# Altium schematic sheet [03] - MyUno SCHEMATIC.SchDoc
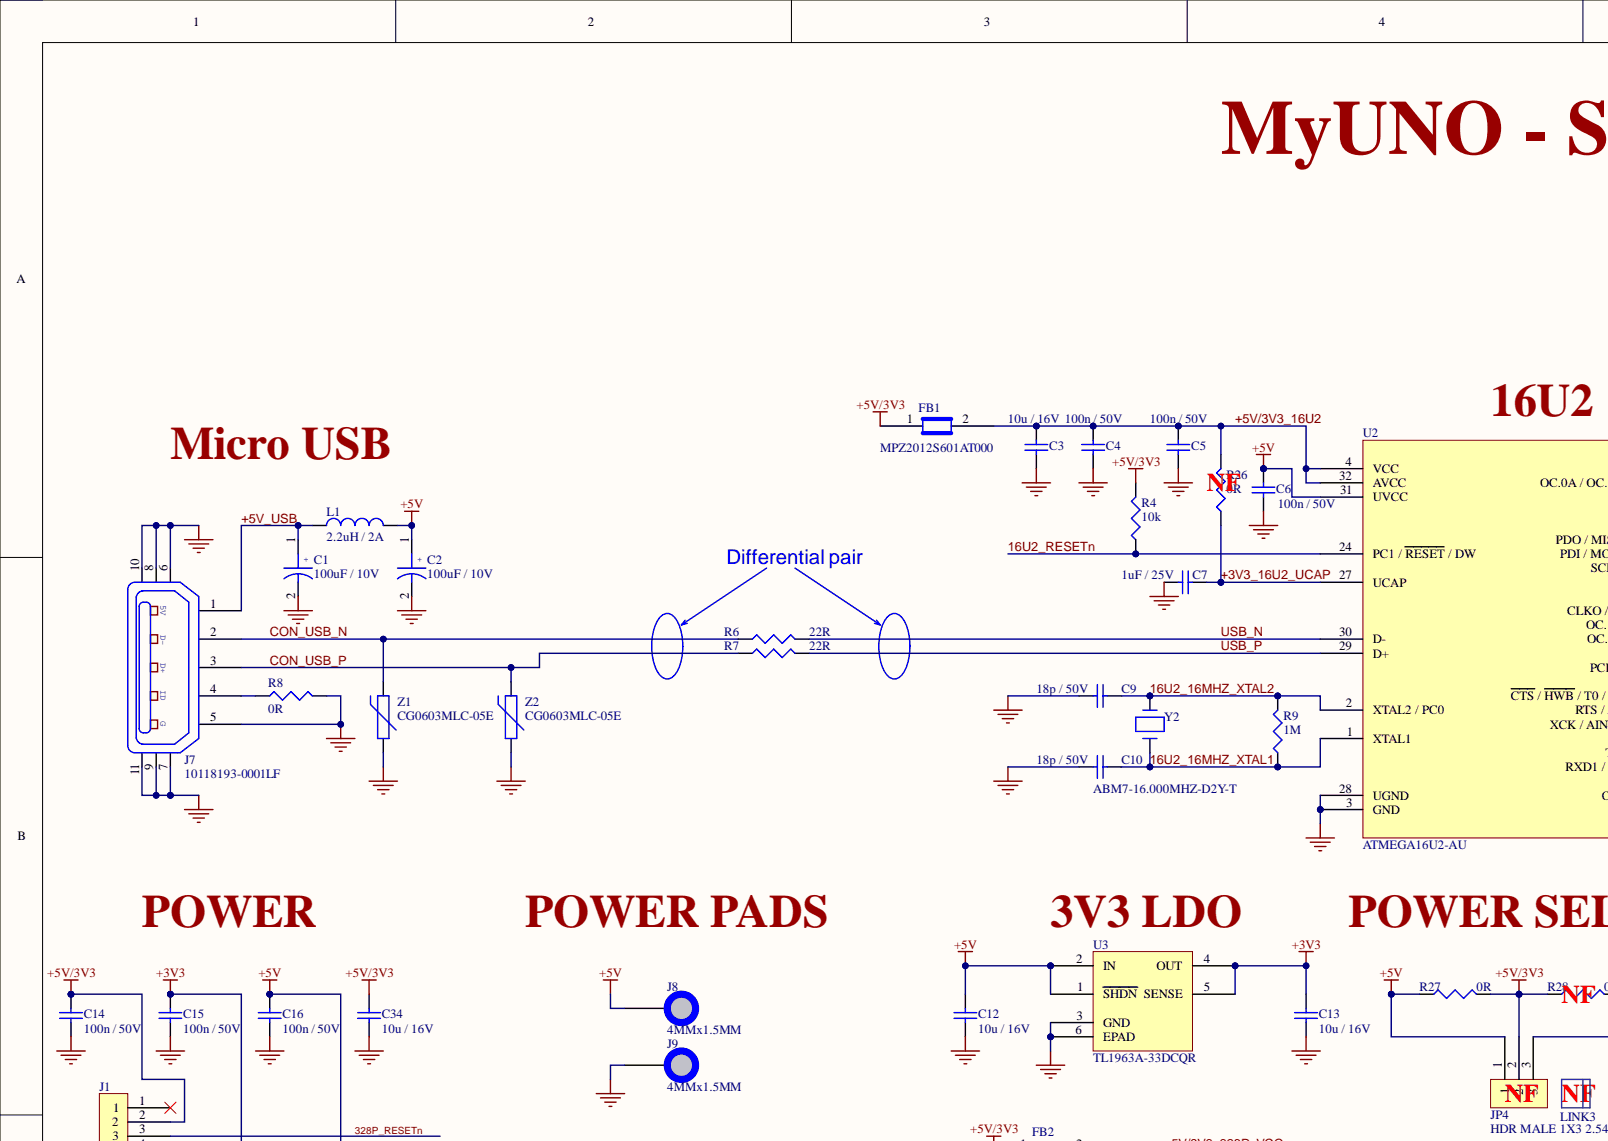
[diagram p0: [03] - MyUno SCHEMATIC.SchDoc, top left]
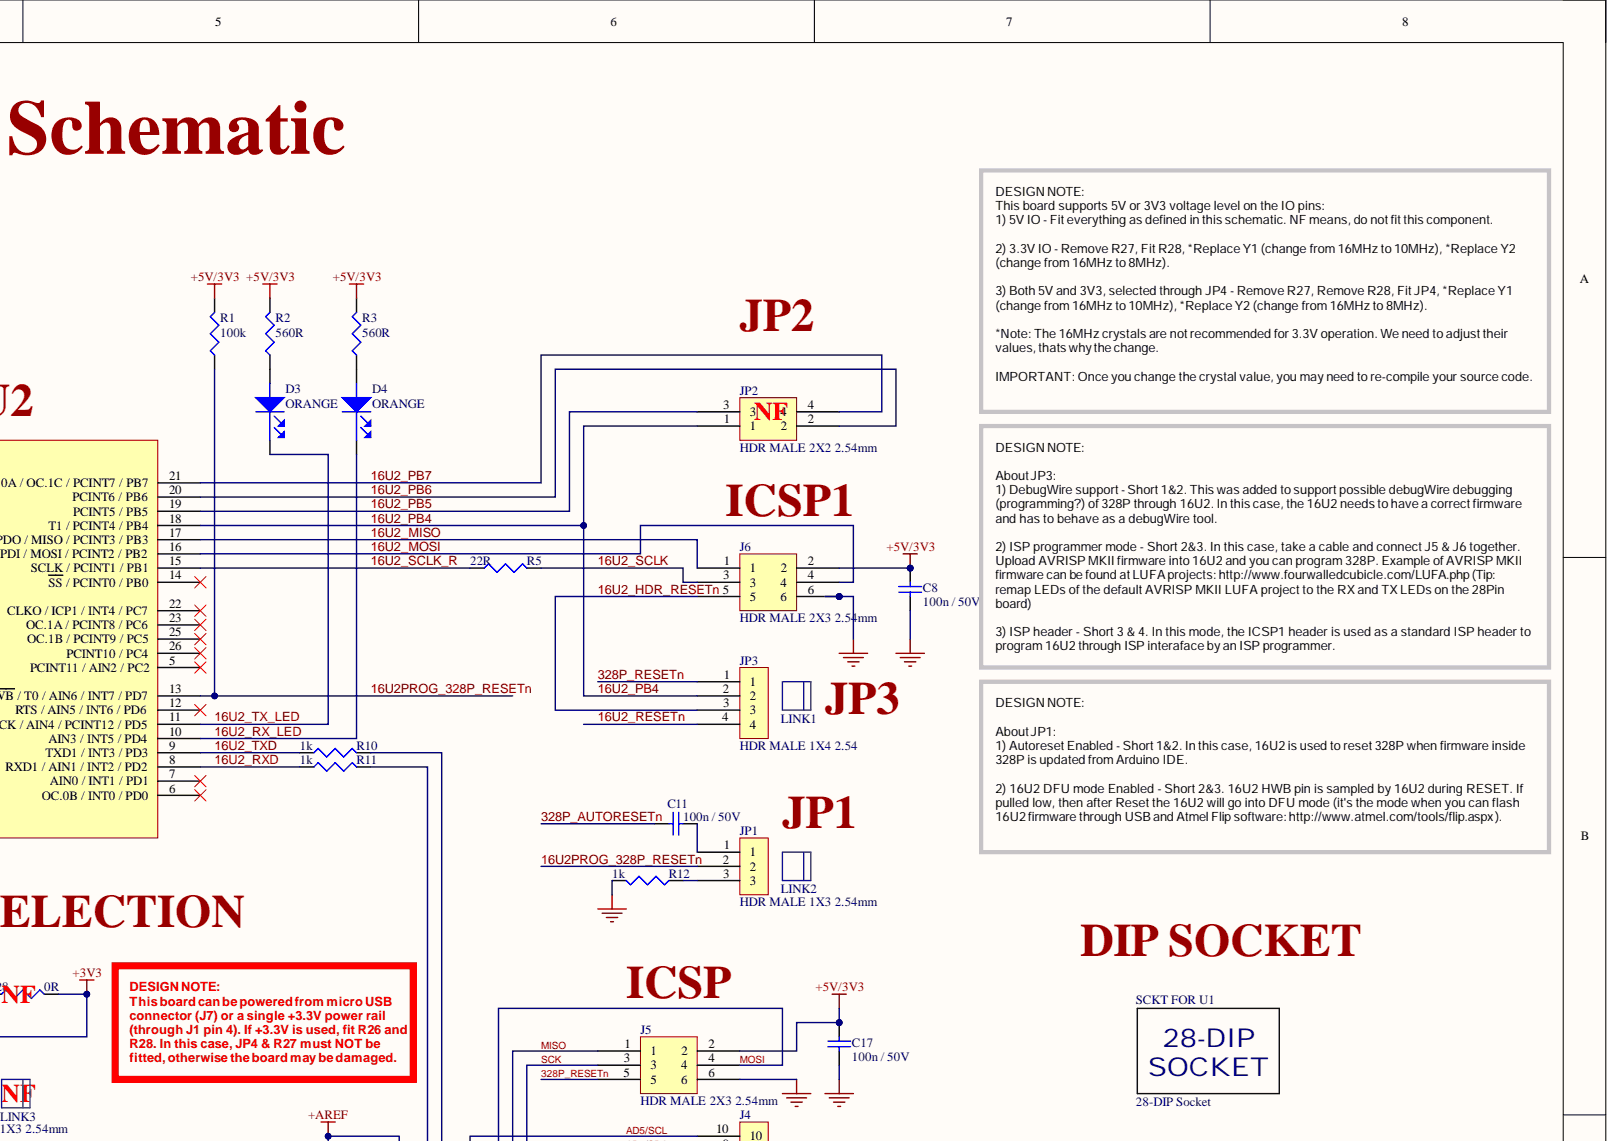
[diagram p1: [03] - MyUno SCHEMATIC.SchDoc, top right]
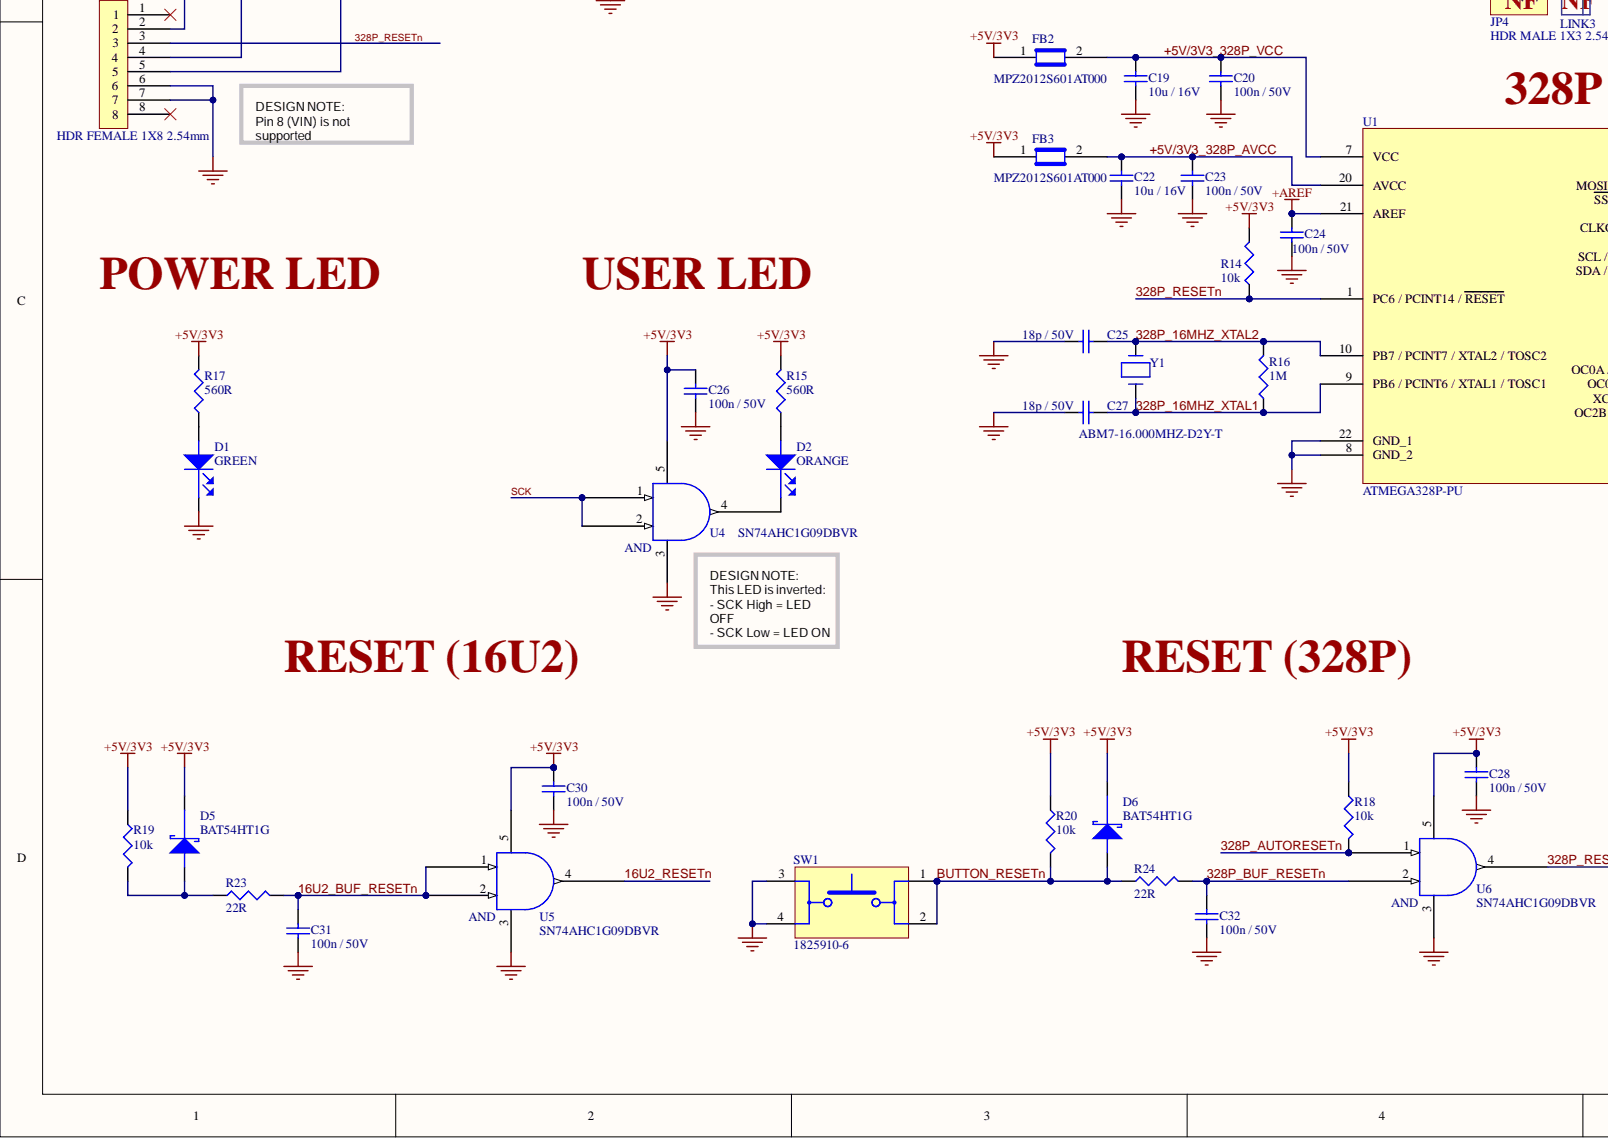
[diagram p2: [03] - MyUno SCHEMATIC.SchDoc, bottom left]
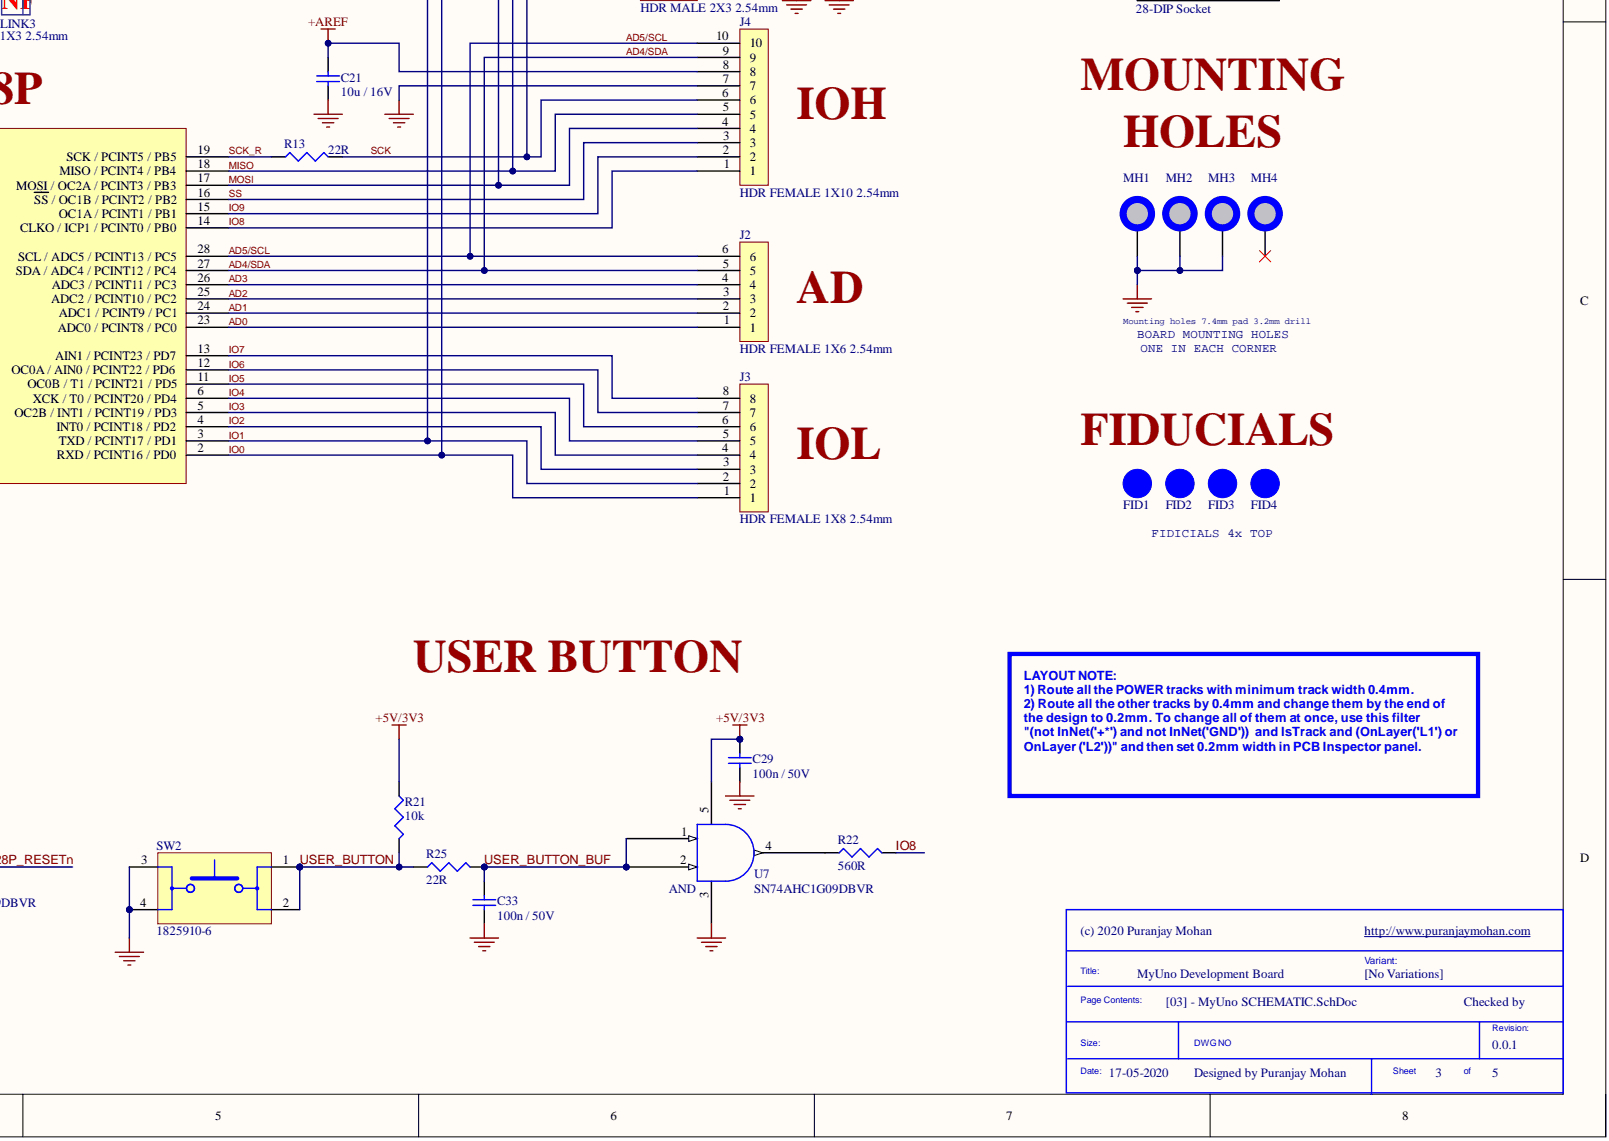
[diagram p3: [03] - MyUno SCHEMATIC.SchDoc, bottom right]

## Parts
C1  100uF / 10V  Capacitance: 100uF  [C_ALU_C_SIZE]
C2  100uF / 10V  Capacitance: 100uF  Voltage Rating: 10V  [C_ALU_C_SIZE]
C3  10u / 16V  Voltage Rating: 16V  [C0805]
C4  100n / 50V  Voltage: 50V  [C0805]
C5  100n / 50V  Voltage: 50V  [C0805]
C6  100n / 50V  [C0805]
C7  1uF / 25V  Voltage: 25V  [C0805]
C8  100n / 50V  Voltage: 50V  [C0805]
C9  18p / 50V  Voltage: 50V  [C0805]
C10  18p / 50V  Voltage: 50V  [C0805]
C11  100n / 50V  [C0805]
C12  10u / 16V  Voltage Rating: 16V  [C0805]
C13  10u / 16V  Voltage Rating: 16V  [C0805]
C14  100n / 50V  [C0805]
C15  100n / 50V  Voltage: 50V  [C0805]
C16  100n / 50V  Voltage: 50V  [C0805]
C17  100n / 50V  Voltage: 50V  [C0805]
C19  10u / 16V  [C0805]
C20  100n / 50V  Voltage: 50V  [C0805]
C21  10u / 16V  Voltage Rating: 16V  [C0805]
C22  10u / 16V  [C0805]
C23  100n / 50V  Voltage: 50V  [C0805]
C24  100n / 50V  [C0805]
C25  18p / 50V  Voltage: 50V  [C0805]
C26  100n / 50V  [C0805]
C27  18p / 50V  Voltage: 50V  [C0805]
C28  100n / 50V  [C0805]
C29  100n / 50V  [C0805]
C30  100n / 50V  [C0805]
C31  100n / 50V  Voltage: 50V  [C0805]
C32  100n / 50V  Voltage: 50V  [C0805]
C33  100n / 50V  Voltage: 50V  [C0805]
C34  10u / 16V  Voltage Rating: 16V  [C0805]
D1  GREEN  [LED_0603]
D2  ORANGE  [LED_0603]
D3  ORANGE  [LED_0603]
D4  ORANGE  [LED_0603]
D5  BAT54HT1G  [SOD323]
D6  BAT54HT1G  [SOD323]
FB1  MPZ2012S601AT000  [C0805]
FB2  MPZ2012S601AT000  [C0805]
FB3  MPZ2012S601AT000  [C0805]
FID1  FID_1MM  [FID_40x120]  (value not drawn)  (no BOM)
FID2  FID_1MM  [FID_40x120]  (value not drawn)  (no BOM)
FID3  FID_1MM  [FID_40x120]  (value not drawn)  (no BOM)
FID4  FID_1MM  [FID_40x120]  (value not drawn)  (no BOM)
J1  HDR FEMALE 1X8 2.54mm  Pitch: 2.54mm  [HDR_FEMALE_1x8_2.54mm]
J2  HDR FEMALE 1X6 2.54mm  [HDR_FEMALE_1x6_2.54mm]
J3  HDR FEMALE 1X8 2.54mm  [HDR_FEMALE_1x8_2.54mm]
J4  HDR FEMALE 1X10 2.54mm  Lead Pitch: 2.54mm  [HDR_FEMALE_1x10_2.54mm]
J5  HDR MALE 2X3 2.54mm  Pitch: 2.54mm  [HRD_2X3_2.54MM]
J6  HDR MALE 2X3 2.54mm  Pitch: 2.54mm  [HRD_2X3_2.54MM]
J7  10118193-0001LF  [10118193]
J8  4MMx1.5MM  [PAD_WITH_HOLW_4MMX1.5MM]  (no BOM)
J9  4MMx1.5MM  [PAD_WITH_HOLW_4MMX1.5MM]  (no BOM)
JP1  HDR MALE 1X3 2.54mm  [HDR_MALE_1x3_2.54mm]
JP2  HDR MALE 2X2 2.54mm  Pitch: 2.54mm  [HRD_2X2_2.54MM]
JP3  HDR MALE 1X4 2.54  [HDR_MALE_1x4_2.54mm]
JP4  HDR MALE 1X3 2.54mm  [HDR_MALE_1x3_2.54mm]
L1  2.2uH / 2A  Current Rating: 2A  Inductance: 2.2uH  [C1210_IND]
LINK1  LINK  (mechanical)
LINK2  LINK  (mechanical)
LINK3  LINK  (mechanical)
MH1  MH_7.4MM_3.2MM  [MH_7.4MMD_3.2MMD]  (value not drawn)  (no BOM)
MH2  MH_7.4MM_3.2MM  [MH_7.4MMD_3.2MMD]  (value not drawn)  (no BOM)
MH3  MH_7.4MM_3.2MM  [MH_7.4MMD_3.2MMD]  (value not drawn)  (no BOM)
MH4  MH_7.4MMNP_3.2MM  [MH_7.4MMD_3.2MMD_NON_COPPER_NPTH]  (value not drawn)  (no BOM)
R1  100k  [R0805]
R2  560R  [R0805]
R3  560R  [R0805]
R4  10k  [R0805]
R5  22R  [R0805]
R6  22R  [R0805]
R7  22R  [R0805]
R8  0R  [R0805]
R9  1M  [R0805]
R10  1k  [R0805]
R11  1k  [R0805]
R12  1k  [R0805]
R13  22R  [R0805]
R14  10k  [R0805]
R15  560R  [R0805]
R16  1M  [R0805]
R17  560R  [R0805]
R18  10k  [R0805]
R19  10k  [R0805]
R20  10k  [R0805]
R21  10k  [R0805]
R22  560R  [R0805]
R23  22R  [R0805]
R24  22R  [R0805]
R25  22R  [R0805]
R26  0R  [R0805]
R27  0R  [R0805]
R28  0R  [R0805]
SCKT_FOR_U1  28-DIP Socket  [28_DIP_7.62mm_SOCKET]
SW1  1825910-6  [1825910-6]
SW2  1825910-6  [1825910-6]
U1  ATMEGA328P-PU  [28_DIP_7.62mm]
U2  ATMEGA16U2-AU  [TQFP32]
U3  TL1963A-33DCQR  [DCQ (R-PDSO-G6)]
U4  SN74AHC1G09DBVR  Logic Function: AND  [DBV_SOT_23_5]
U5  SN74AHC1G09DBVR  Logic Function: AND  [DBV_SOT_23_5]
U6  SN74AHC1G09DBVR  Logic Function: AND  [DBV_SOT_23_5]
U7  SN74AHC1G09DBVR  Logic Function: AND  [DBV_SOT_23_5]
Y1  ABM7-16.000MHZ-D2Y-T  [ABM7_V1_1_BIG]
Y2  ABM7-16.000MHZ-D2Y-T  [ABM7_V1_1_BIG]
Z1  CG0603MLC-05E  [R0603]
Z2  CG0603MLC-05E  [R0603]

## Nets
+3V3: C13.2 C15.2 J1.4 JP4.3 R28.2 U3.4(OUT) U3.5(SENSE)
+5V: C2.1 C6.2 C12.2 C16.2 J1.5 J8.1 JP4.1 L1.2 R27.1 U2.31(UVCC) U3.1(SHDN) U3.2(IN)
+5V/3V3: C8.2 C14.2 C17.2 C26.2 C28.2 C29.2 C30.2 C34.2 D5.1 D6.1 FB1.1 FB2.1 FB3.1 J1.2 J5.2 J6.2 JP4.2 R1.2 R2.2 R3.2 R4.2 R14.2 R15.2 R17.2 R18.1 R19.1 R20.1 R21.1 R27.2 R28.1 U4.5 U5.5 U6.5 U7.5
+AREF: C21.2 C24.2 J4.8 U1.21(AREF)
GND: C1.2 C2.2 C3.1 C4.1 C5.1 C6.1 C7.1 C8.1 C9.1 C10.1 C12.1 C13.1 C14.1 C15.1 C16.1 C17.1 C19.1 C20.1 C21.1 C22.1 C23.1 C24.1 C25.1 C26.1 C27.1 C28.1 C29.1 C30.1 C31.1 C32.1 C33.1 C34.1 D1.1 J1.6 J1.7 J4.7 J5.6 J6.6 J7.5 J7.6 J7.7 J7.8 J7.9 J7.10 J7.11 J9.1 MH1.1 MH2.1 MH3.1 R8.2 R12.2 SW1.3 SW1.4 SW2.3 SW2.4 U1.8(GND_2) U1.22(GND_1) U2.3(GND) U2.28(UGND) U3.3(GND) U3.6(EPAD) U4.3 U5.3 U6.3 U7.3 Z1.1 Z2.1
16U2_16MHZ_XTAL1: C10.2 R9.1 U2.1(XTAL1) Y2.1
16U2_16MHZ_XTAL2: C9.2 R9.2 U2.2(XTAL2_/_PC0) Y2.2
16U2_BUF_RESETn: C31.2 R23.2 U5.1 U5.2
16U2_HDR_RESETn: J6.5 JP3.3
16U2_MISO: J6.1 U2.17(PDO_/_MISO_/_PCINT3_/_PB3)
16U2_MOSI: J6.4 U2.16(PDI_/_MOSI_/_PCINT2_/_PB2)
16U2_PB4: JP2.1 JP3.2 U2.18(T1_/_PCINT4_/_PB4)
16U2_PB5: JP2.3 U2.19(PCINT5_/_PB5)
16U2_PB6: JP2.2 U2.20(PCINT6_/_PB6)
16U2_PB7: JP2.4(NF) U2.21(OC.0A_/_OC.1C_/_PCINT7_/_PB7)
16U2_RESETn: JP3.4 R4.1 U2.24(PC1_/_RESET_/_DW) U5.4
16U2_RX_LED: D4.1 U2.10(AIN3_/_INT5_/_PD4)
16U2_RXD: R11.1 U2.8(RXD1_/_AIN1_/_INT2_/_PD2)
16U2_SCLK: J6.3 R5.2
16U2_SCLK_R: R5.1 U2.15(SCLK_/_PCINT1_/_PB1)
16U2_TX_LED: D3.1 U2.11(XCK_/_AIN4_/_PCINT12_/_PD5)
16U2_TXD: R10.1 U2.9(TXD1_/_INT3_/_PD3)
16U2PROG_328P_RESETn: JP1.2 R1.1 U2.13(CTS_/_HWB_/_T0_/_AIN6_/_INT7_/_PD7)
328P_16MHZ_XTAL1: C27.2 R16.1 U1.9(PB6_/_PCINT6_/_XTAL1_/_TOSC1) Y1.1
328P_16MHZ_XTAL2: C25.2 R16.2 U1.10(PB7_/_PCINT7_/_XTAL2_/_TOSC2) Y1.2
328P_AUTORESETn: C11.2 R18.2 U6.1
328P_BUF_RESETn: C32.2 R24.2 U6.2
328P_RESETn: J1.3 J5.5 JP3.1 R14.1 U1.1(PC6_/_PCINT14_/_RESET) U6.4
+3V3_16U2_UCAP: C7.2 R26.1 U2.27(UCAP)
+5V/3V3_16U2: C3.2 C4.2 C5.2 FB1.2 R26.2 U2.4(VCC) U2.32(AVCC)
+5V/3V3_328P_AVCC: C22.2 C23.2 FB3.2 U1.20(AVCC)
+5V/3V3_328P_VCC: C19.2 C20.2 FB2.2 U1.7(VCC)
+5V_USB: C1.1 J7.1 L1.1
AD0: J2.1 U1.23(ADC0_/_PCINT8_/_PC0)
AD1: J2.2 U1.24(ADC1_/_PCINT9_/_PC1)
AD2: J2.3 U1.25(ADC2_/_PCINT10_/_PC2)
AD3: J2.4 U1.26(ADC3_/_PCINT11_/_PC3)
AD4/SDA: J2.5 J4.9 U1.27(SDA_/_ADC4_/_PCINT12_/_PC4)
AD5/SCL: J2.6 J4.10 U1.28(SCL_/_ADC5_/_PCINT13_/_PC5)
BUTTON_RESETn: D6.2 R20.2 R24.1 SW1.1 SW1.2
CON_USB_N: J7.2 R6.1 Z1.2
CON_USB_P: J7.3 R7.1 Z2.2
IO0: J3.1 R10.2 U1.2(RXD_/_PCINT16_/_PD0)
IO1: J3.2 R11.2 U1.3(TXD_/_PCINT17_/_PD1)
IO2: J3.3 U1.4(INT0_/_PCINT18_/_PD2)
IO3: J3.4 U1.5(OC2B_/_INT1_/_PCINT19_/_PD3)
IO4: J3.5 U1.6(XCK_/_T0_/_PCINT20_/_PD4)
IO5: J3.6 U1.11(OC0B_/_T1_/_PCINT21_/_PD5)
IO6: J3.7 U1.12(OC0A_/_AIN0_/_PCINT22_/_PD6)
IO7: J3.8 U1.13(AIN1_/_PCINT23_/_PD7)
IO8: J4.1 R22.2 U1.14(CLKO_/_ICP1_/_PCINT0_/_PB0)
IO9: J4.2 U1.15(OC1A_/_PCINT1_/_PB1)
MISO: J4.5 J5.1 U1.18(MISO_/_PCINT4_/_PB4)
MOSI: J4.4 J5.4 U1.17(MOSI_/_OC2A_/_PCINT3_/_PB3)
SCK: J4.6 J5.3 R13.2 U4.1 U4.2
SCK_R: R13.1 U1.19(SCK_/_PCINT5_/_PB5)
SS: J4.3 U1.16(SS_/_OC1B_/_PCINT2_/_PB2)
USB_N: R6.2 U2.30(D-)
USB_P: R7.2 U2.29(D+)
USER_BUTTON: R21.2 R25.1 SW2.1 SW2.2
USER_BUTTON_BUF: C33.2 R25.2 U7.1 U7.2
NetC11_1: C11.1 JP1.1
NetD1_2: D1.2 R17.1
NetD2_1: D2.1 U4.4
NetD2_2: D2.2 R15.1
NetD3_2: D3.2 R2.1
NetD4_2: D4.2 R3.1
NetD5_2: D5.2 R19.2 R23.1
NetJ7_4: J7.4 R8.1
NetJP1_3: JP1.3 R12.1
NetR22_1: R22.1 U7.4

Not connected: J1 1 8; MH4 1; U2 5(PCINT11_/_AIN2_/_PC2) 6(OC.0B_/_INT0_/_PD0) 7(AIN0_/_INT1_/_PD1) 12(RTS_/_AIN5_/_INT6_/_PD6) 14(SS_/_PCINT0_/_PB0) 22(CLKO_/_ICP1_/_INT4_/_PC7) 23(OC.1A_/_PCINT8_/_PC6) 25(OC.1B_/_PCINT9_/_PC5) 26(PCINT10_/_PC4)
